# Altium schematic sheet 09sata.SchDoc
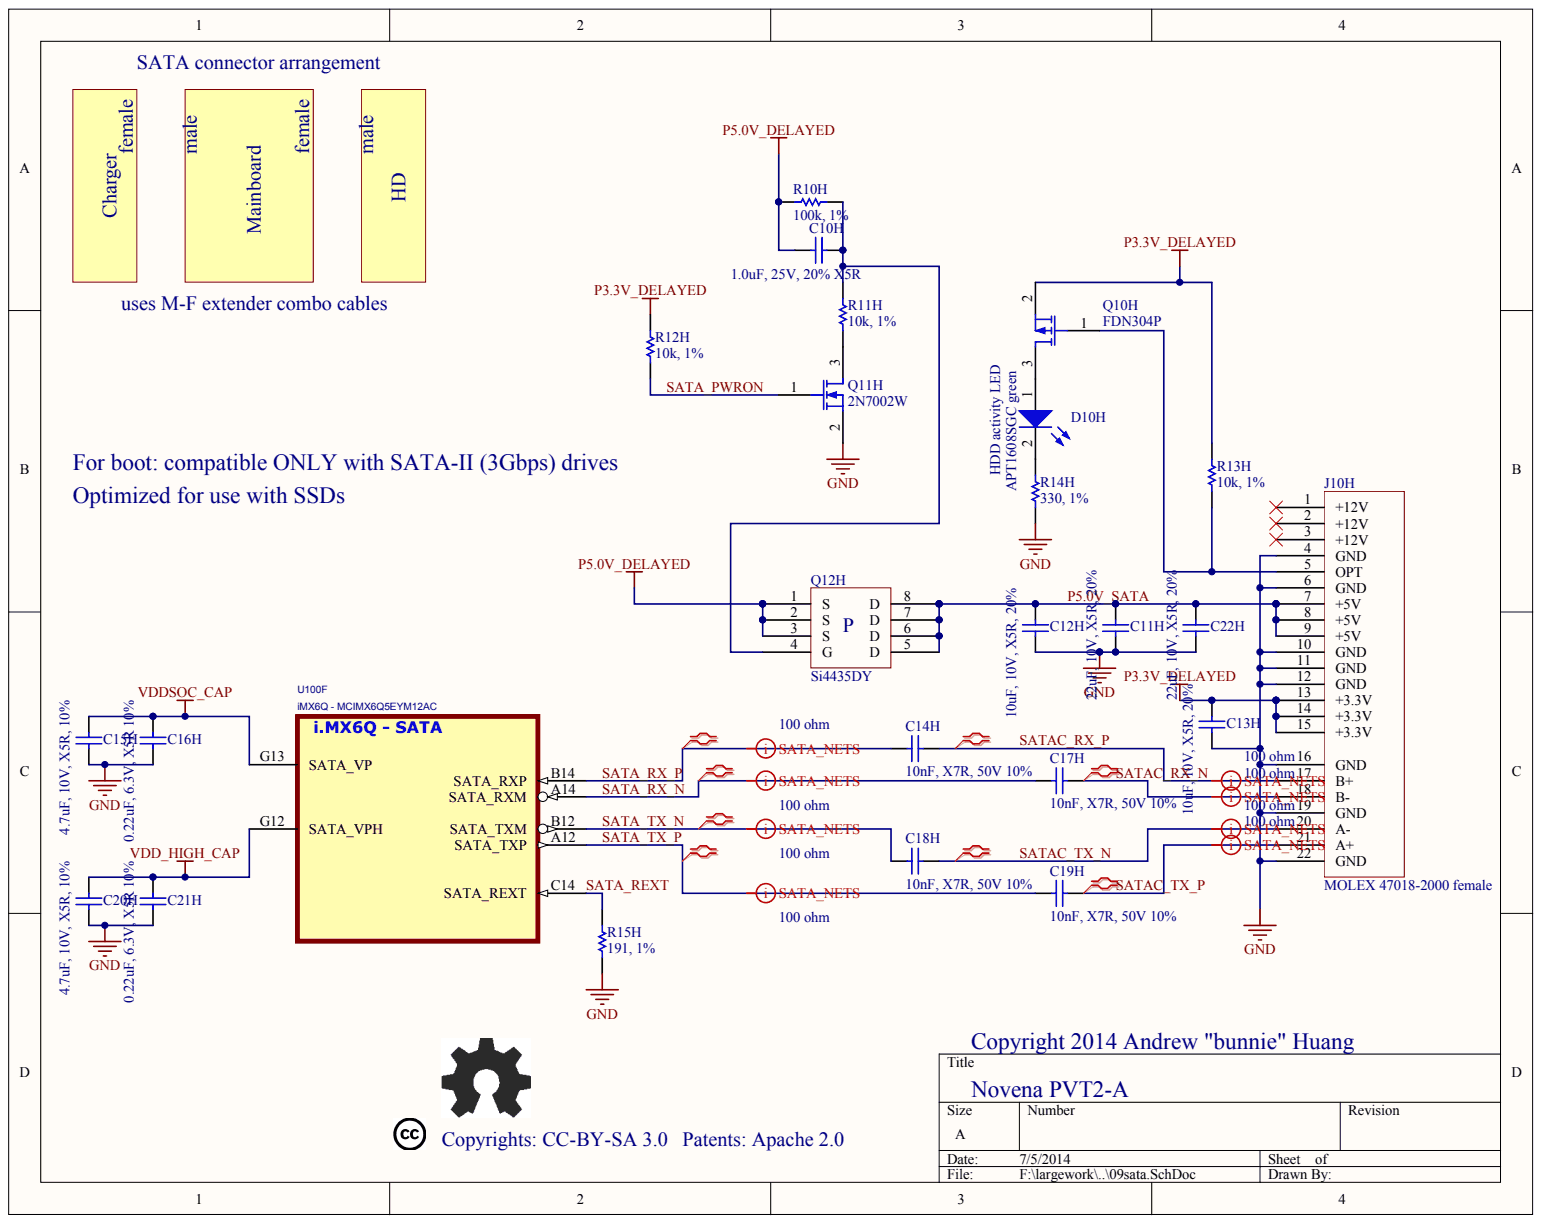
[diagram p0: 09sata.SchDoc]

## Parts
C10H  1.0uF, 25V, 20% X5R
C11H  22uF, 10V, X5R, 20%
C12H  10uF, 10V, X5R, 20%
C13H  10uF, 10V, X5R, 20%
C14H  10nF, X7R, 50V 10%
C15H  4.7uF, 10V, X5R, 10%
C16H  0.22uF, 6.3V, X5R 10%
C17H  10nF, X7R, 50V 10%
C18H  10nF, X7R, 50V 10%
C19H  10nF, X7R, 50V 10%
C20H  4.7uF, 10V, X5R, 10%
C21H  0.22uF, 6.3V, X5R 10%
C22H  22uF, 10V, X5R, 20%
D10H  APT1608SGC green
J10H  MOLEX 47018-2000 female
Q10H  FDN304P
Q11H  2N7002W
Q12H  Si4435DY
R10H  100k, 1%
R11H  10k, 1%
R12H  10k, 1%
R13H  10k, 1%
R14H  330, 1%
R15H  191, 1%
U100  iMX6Q - MCIMX6Q5EYM12AC  (part F)

## Nets
GND: C11H.2 C12H.2 C13H.2 C15H.2 C16H.2 C20H.2 C21H.2 C22H.2 J10H.4(GND) J10H.6(GND) J10H.10(GND) J10H.11(GND) J10H.12(GND) J10H.16(GND) J10H.19(GND) J10H.22(GND) Q11H.2 R14H.1 R15H.2
P3.3V_DELAYED: C13H.1 J10H.13(+3.3V) J10H.14(+3.3V) J10H.15(+3.3V) Q10H.2 R12H.1 R13H.1
P5.0V_DELAYED: C10H.1 Q12H.1(S) Q12H.2(S) Q12H.3(S) R10H.2
VDD_HIGH_CAP: C20H.1 C21H.1 U100.G12(SATA_VPH)
VDDSOC_CAP: C15H.1 C16H.1 U100.G13(SATA_VP)
P5.0V_SATA: C11H.1 C12H.1 C22H.1 J10H.7(+5V) J10H.8(+5V) J10H.9(+5V) Q12H.5(D) Q12H.6(D) Q12H.7(D) Q12H.8(D)
SATA_PWRON: Q11H.1 R12H.2
SATA_REXT: R15H.1 U100.C14(SATA_REXT)
SATA_RX_N: C17H.1 U100.A14(SATA_RXM)
SATA_RX_P: C14H.1 U100.B14(SATA_RXP)
SATA_TX_N: C18H.1 U100.B12(SATA_TXM)
SATA_TX_P: C19H.1 U100.A12(SATA_TXP)
SATAC_RX_N: C17H.2 J10H.18(B-)
SATAC_RX_P: C14H.2 J10H.17(B+)
SATAC_TX_N: C18H.2 J10H.20(A-)
SATAC_TX_P: C19H.2 J10H.21(A+)
NetC10H_2: C10H.2 Q12H.4(G) R10H.1 R11H.1
NetD10H_1: D10H.1 Q10H.3
NetD10H_2: D10H.2 R14H.2
NetJ10H_5: J10H.5(OPT) Q10H.1 R13H.2
NetQ11H_3: Q11H.3 R11H.2

Not connected: J10H 1(+12V) 2(+12V) 3(+12V)

## Library data of the parts
R11H R12H R13H R14H R15H = (no symbol name): Value: 100k; Published: 8-Jun-2000; LatestRevisionDate: 17-Jul-2002; LatestRevisionNote: Re-released for DXP Platform.; PackageReference: AXIAL-0.3; Publisher: Altium Limited
U100 = (no symbol name): AGILE_PN: TMP-WF-14526; MFG_NAME01: Freescale Semiconductor; MFG_PN01: PCIMX6Q6VV1A; PRE_APN: 344-01218; ROHS: Yes; HEIGHT: 2.16MM
C10H C11H C12H C13H C14H C15H C16H C17H C18H C19H C20H C21H C22H D10H J10H Q10H Q11H Q12H R10H = (no symbol name)
  C10H C11H C12H C13H C14H C15H C16H C17H C18H C19H C20H C21H C22H: Published: 8-Jun-2000; LatestRevisionDate: 17-Jul-2002; LatestRevisionNote: Re-released for DXP Platform.; PackageReference: C1206
  D10H: Published: 8-Jun-2000; LatestRevisionDate: 17-Jul-2002; LatestRevisionNote: Re-released for DXP Platform.; PackageVersion: Feb-2002; PackageReference: 3.2X1.6X1.1
  Q10H: Published: 8-Jun-2000; LatestRevisionDate: 17-Jul-2002; LatestRevisionNote: Re-released for DXP Platform.; PackageVersion: 1995/1996; PackageReference: E3; PackageDescription: TO, Flat Index; 3 In-Line, Axial Leads; Body Dia. 4.6mm; Lead Dia. 0.5 mm (max)
  Q11H: Published: 8-Jun-2000; LatestRevisionDate: 17-Jul-2002; LatestRevisionNote: Re-released for DXP Platform.; PackageVersion: 1995/1996; PackageReference: E3
  R10H: Published: 8-Jun-2000; LatestRevisionDate: 17-Jul-2002; LatestRevisionNote: Re-released for DXP Platform.; PackageReference: AXIAL-0.3; Value: 100k
  C10H C11H C12H C13H C14H C15H C16H C17H C18H C19H C20H C21H C22H D10H Q10H Q11H R10H: Publisher: Altium Limited
